# Altium schematic sheet RT_SUPER_B.SchDoc(RT_SUPER_B)
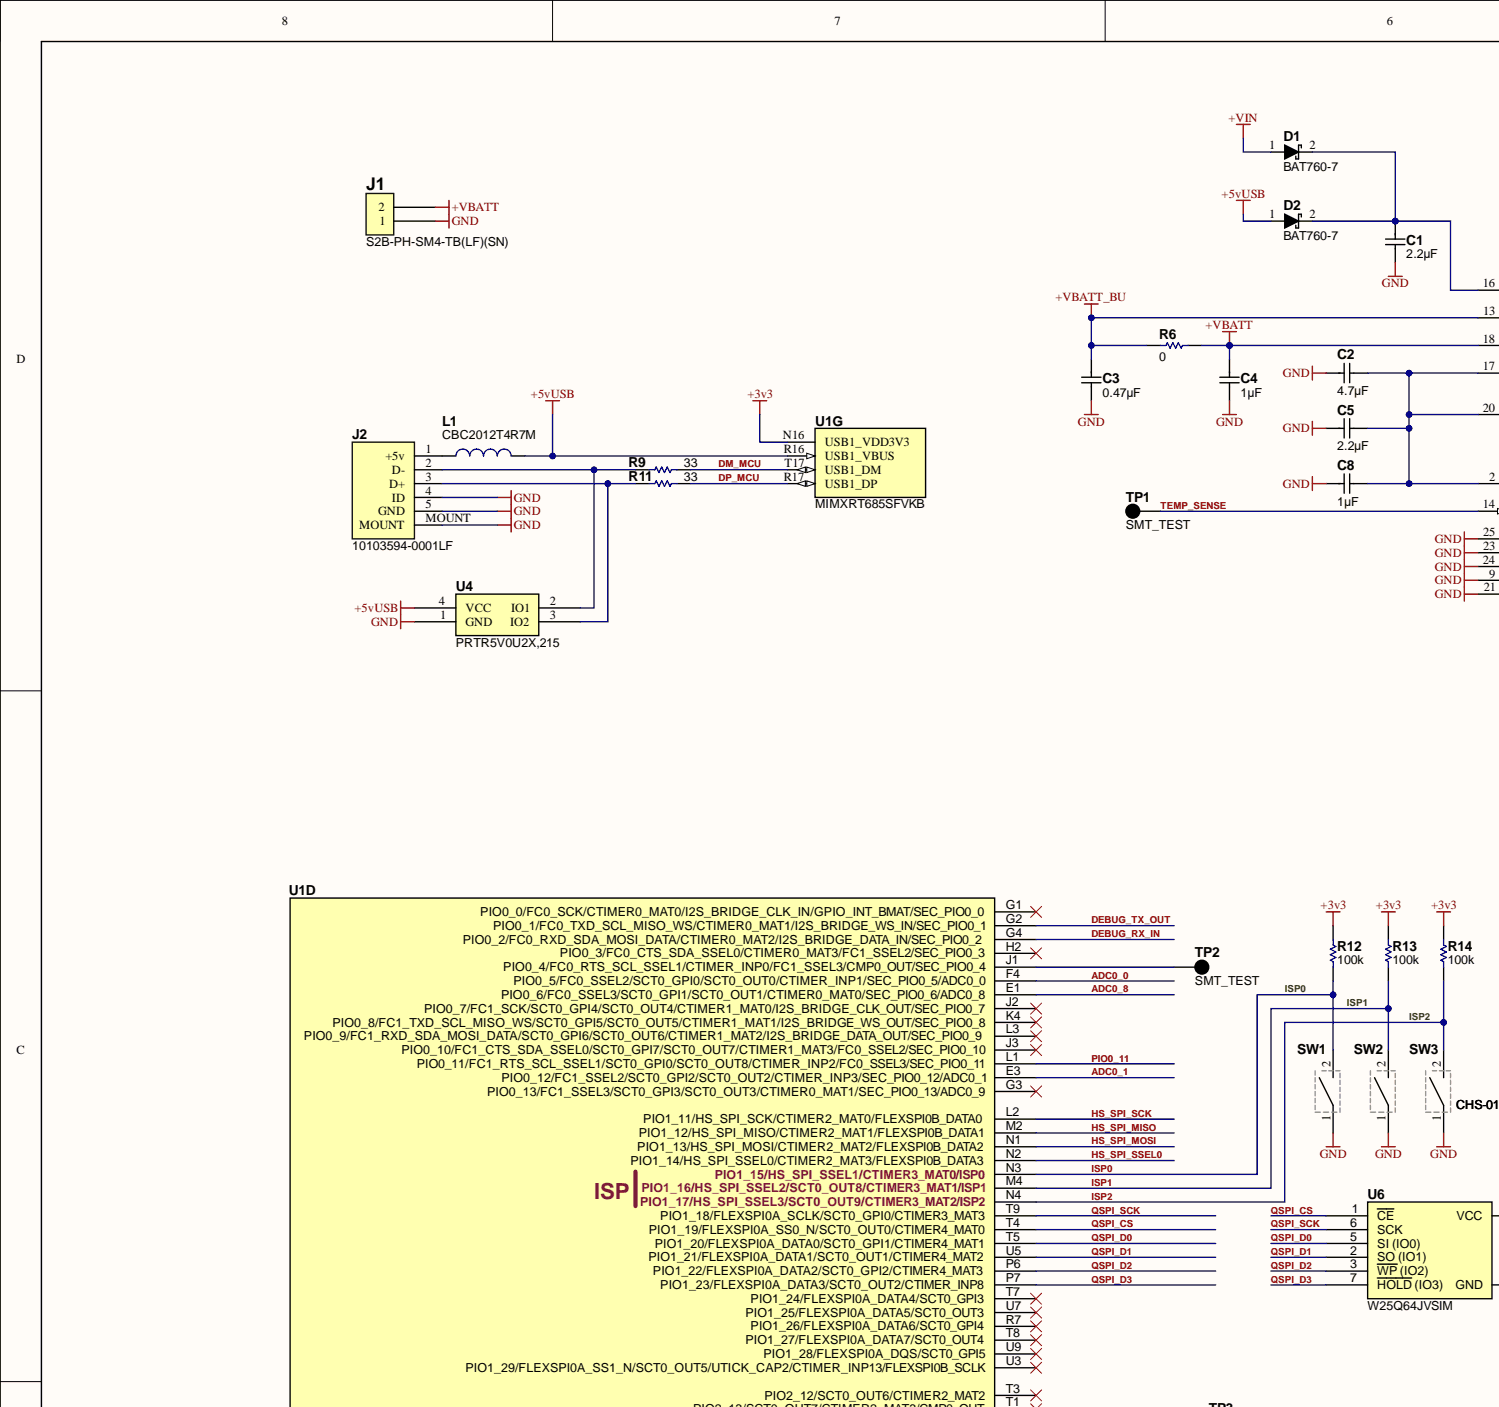
[diagram p0: RT_SUPER_B.SchDoc, top left]
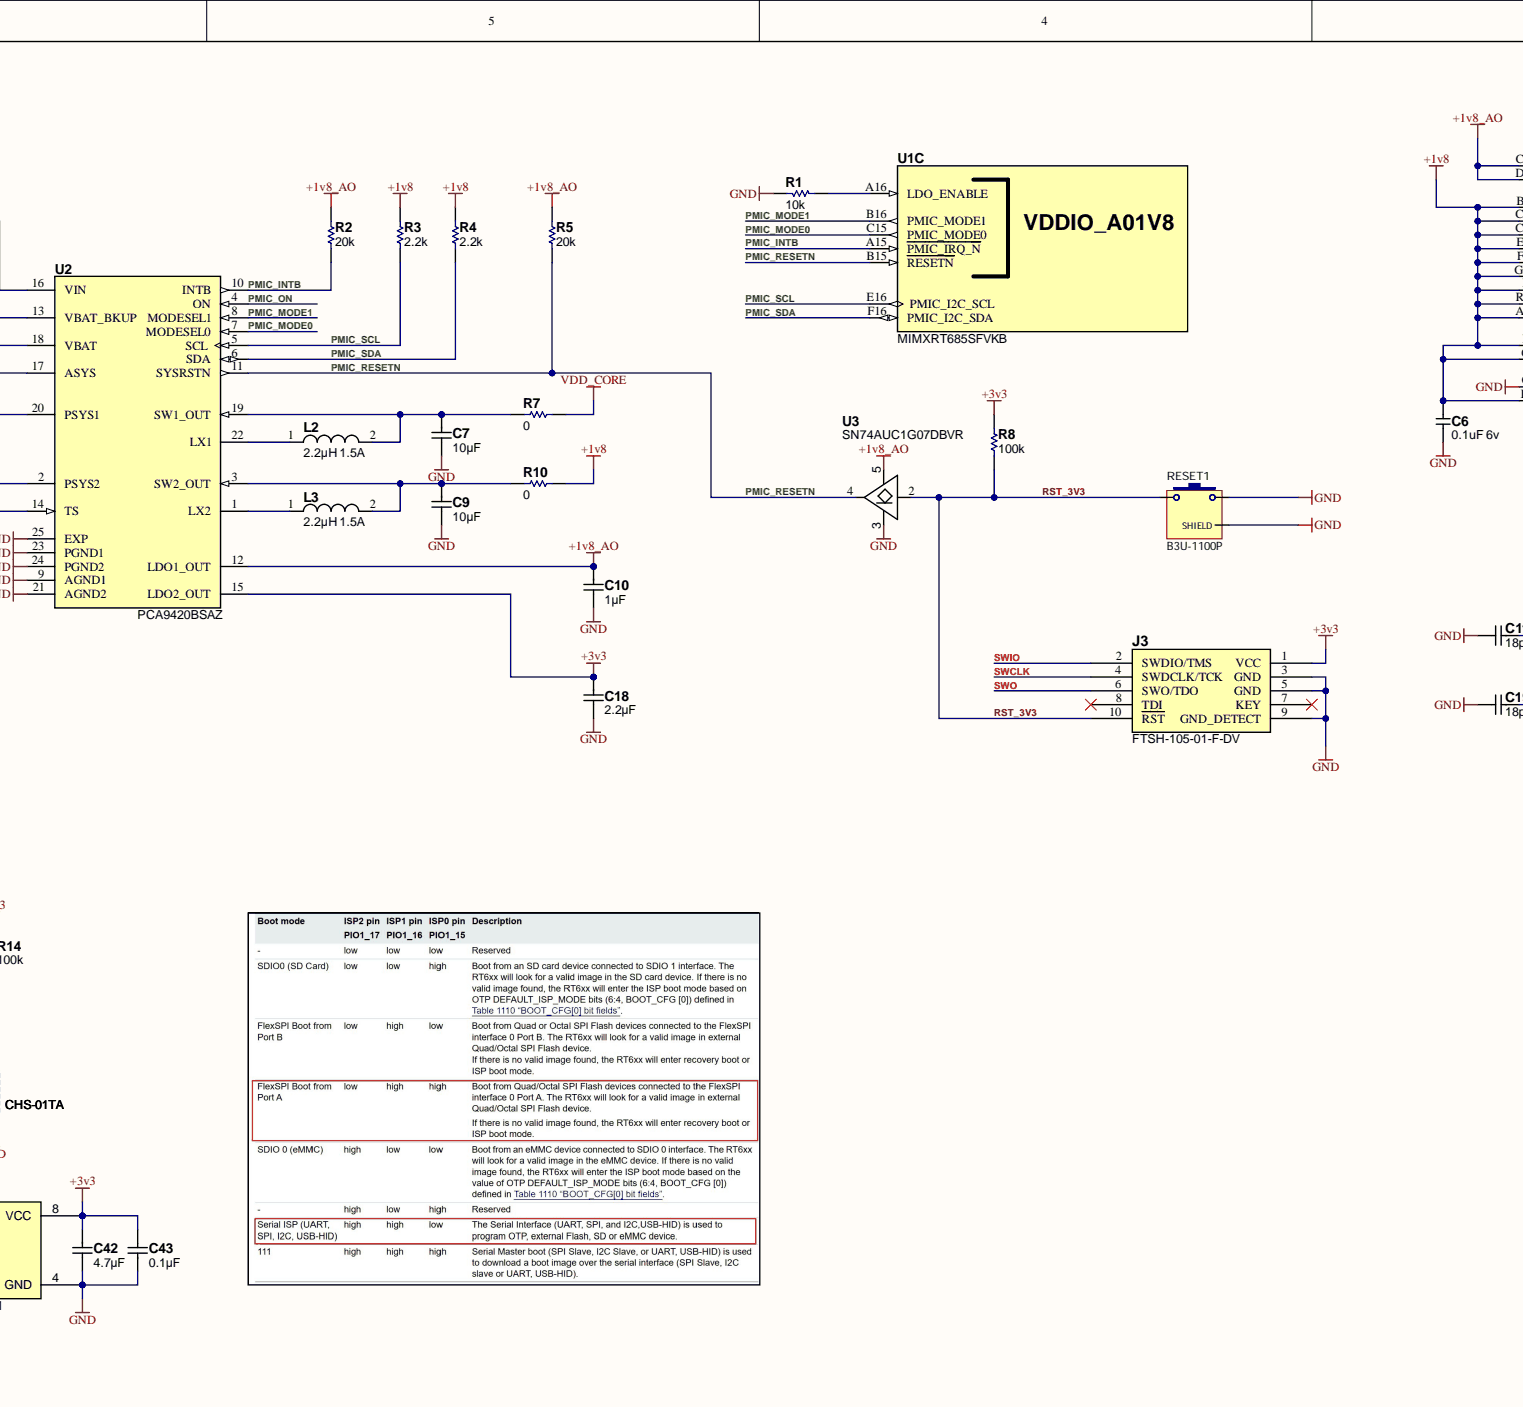
[diagram p1: RT_SUPER_B.SchDoc, top centre]
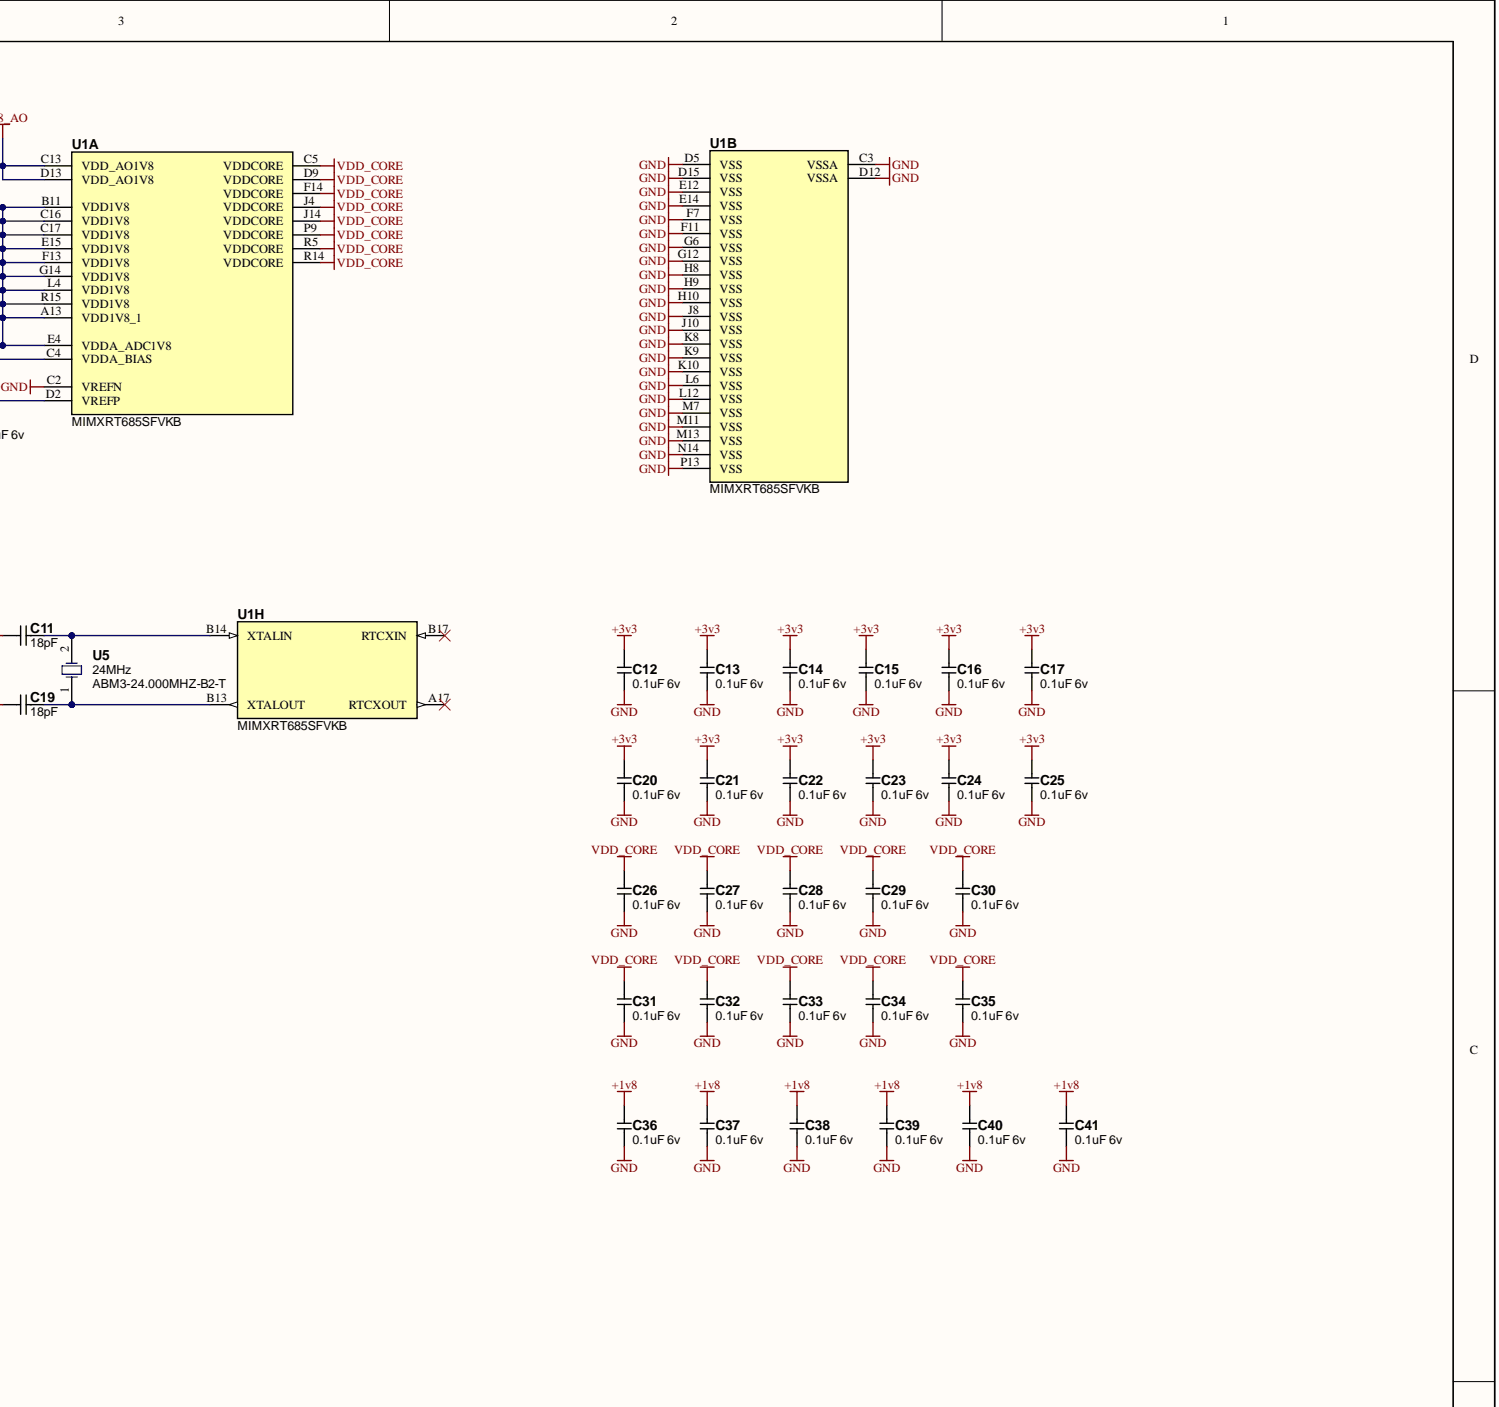
[diagram p2: RT_SUPER_B.SchDoc, top right]
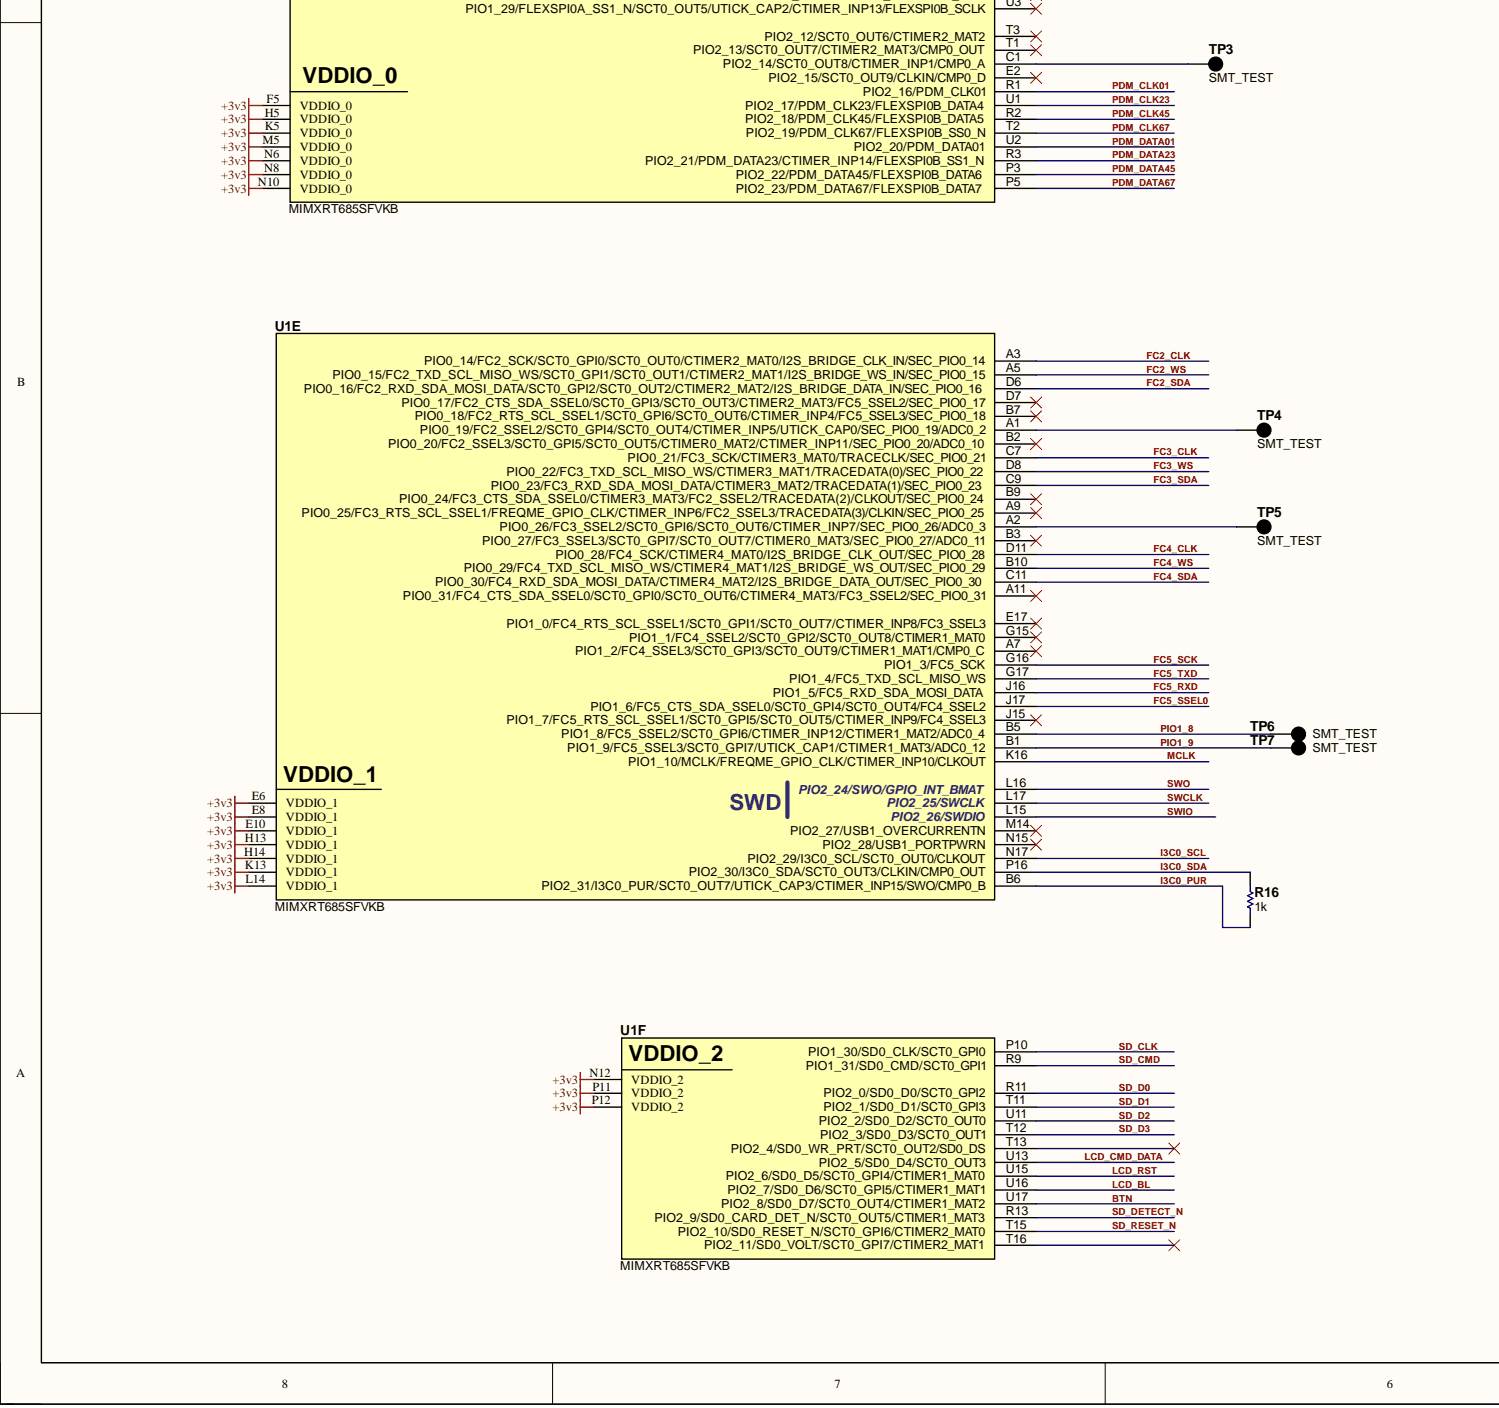
[diagram p3: RT_SUPER_B.SchDoc, bottom left]
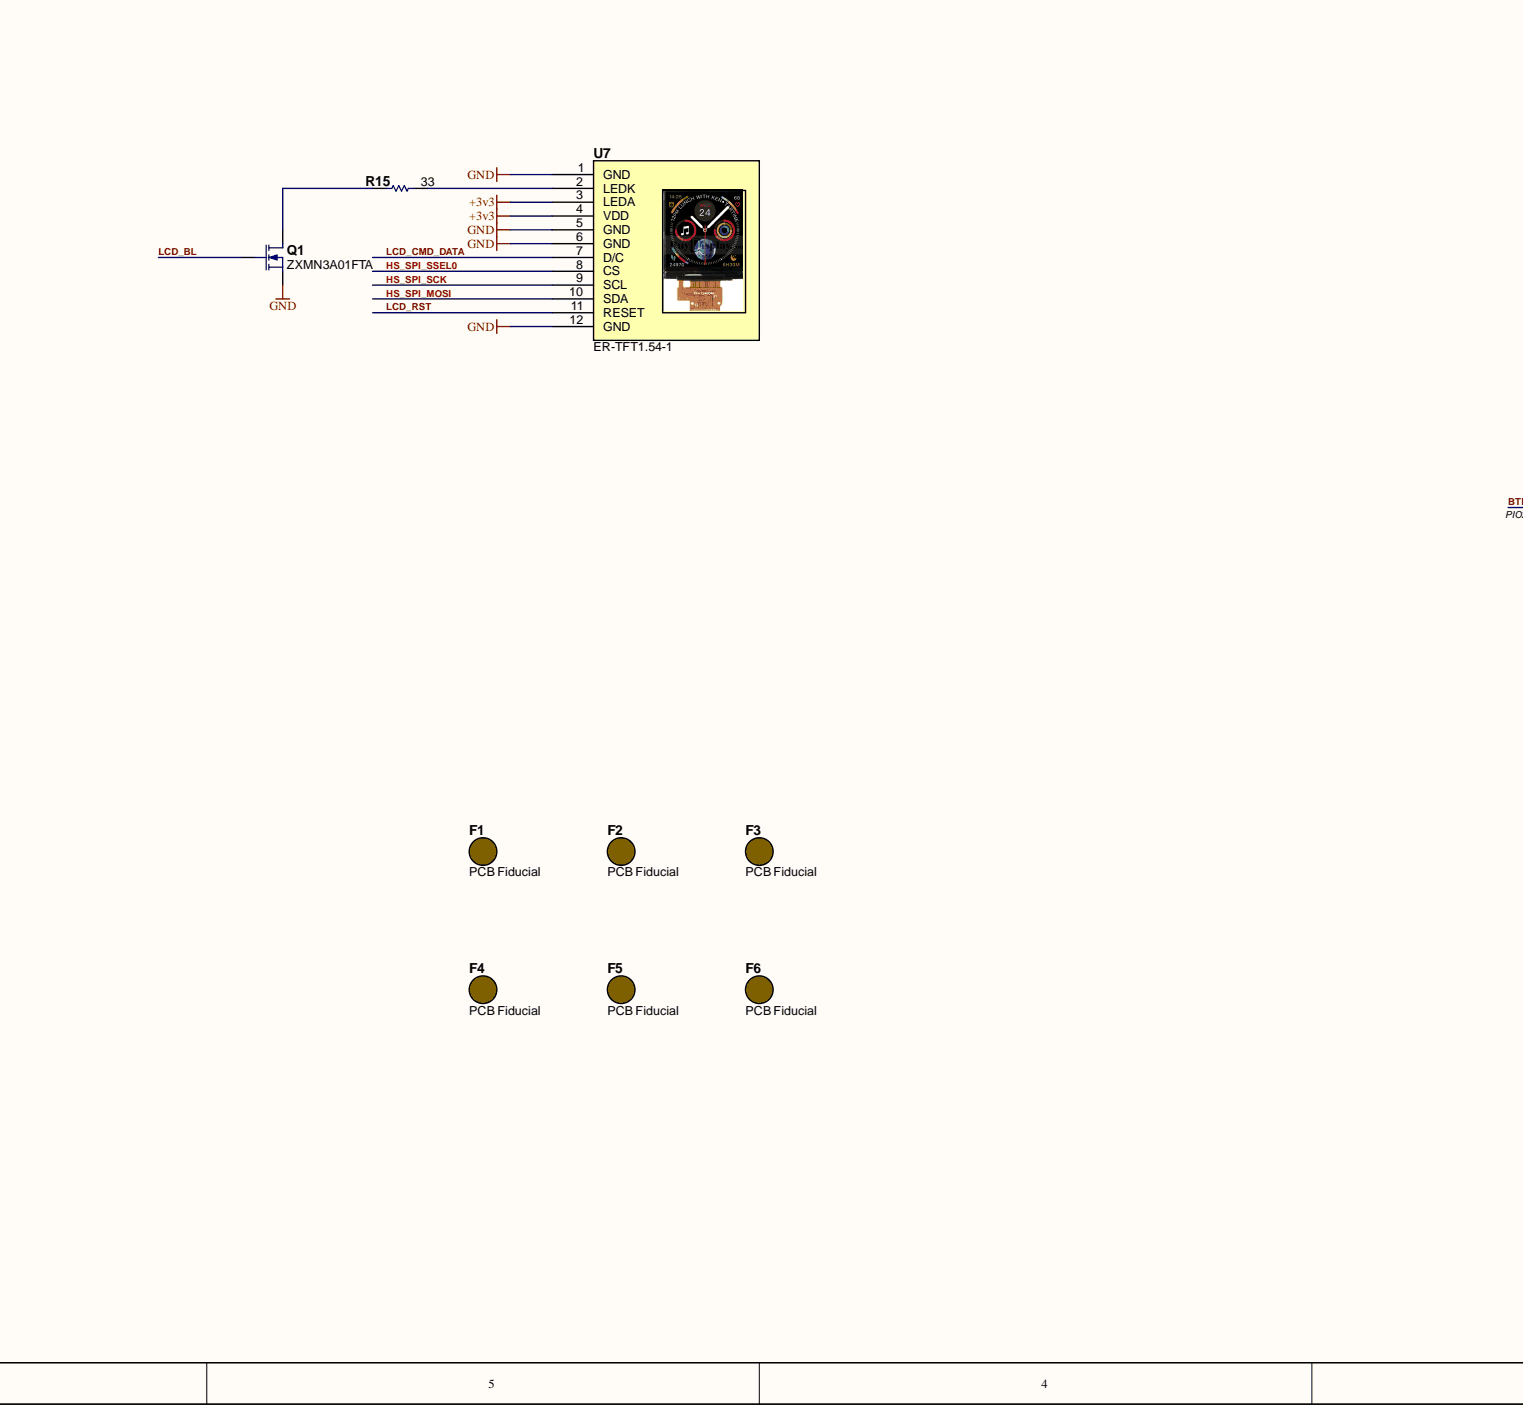
[diagram p4: RT_SUPER_B.SchDoc, bottom centre]
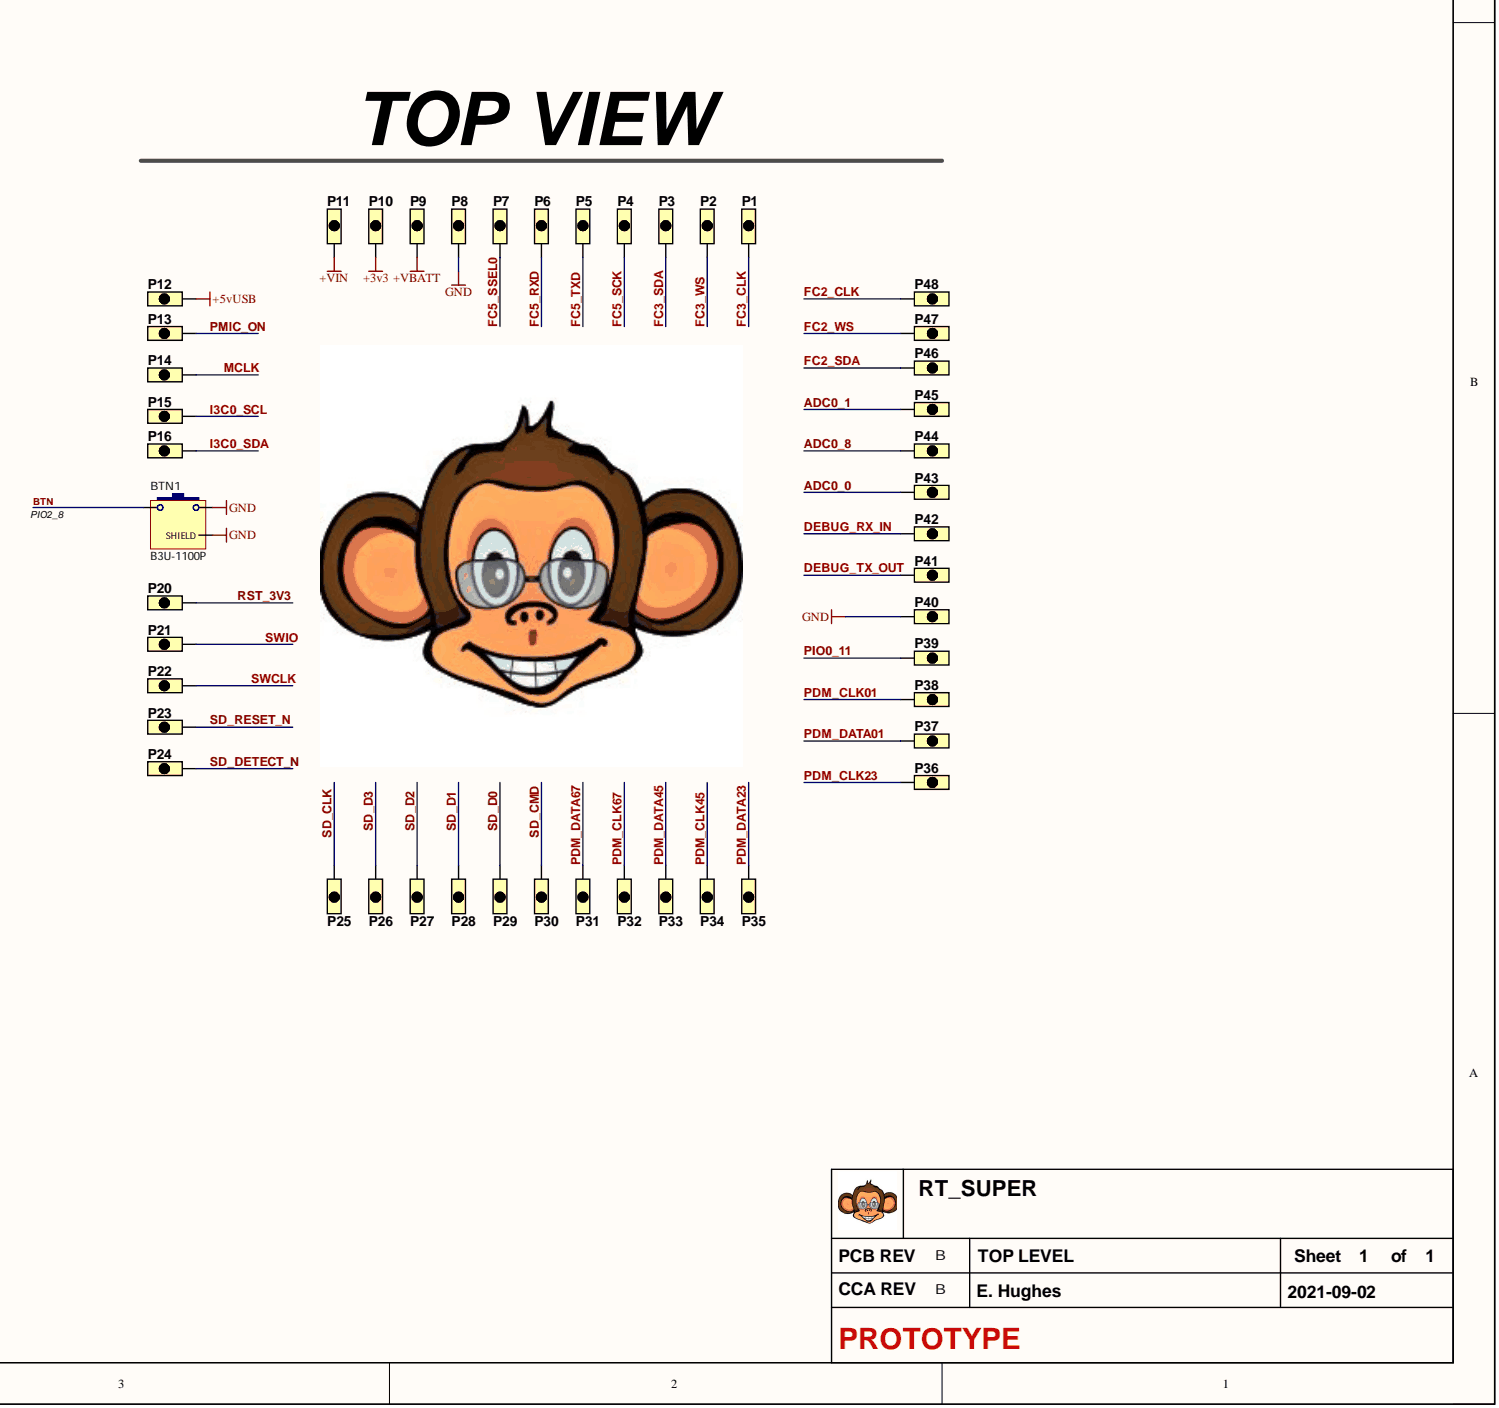
[diagram p5: RT_SUPER_B.SchDoc, bottom right]

## Parts
BTN1  B3U-1100P  [OMRON_B3U-1100P]
C1  2.2µF  [C0603_0.90MM_MD]
C2  4.7µF  [C0603_1.00MM_MD]
C3  0.47µF  [C0402_0.55MM_HD]
C4  1µF  [C0402_0.55MM_HD]
C5  2.2µF  [C0603_0.90MM_MD]
C6  0.1uF 6v  [C0201_PERFECT]
C7  10µF  [C0603_0.90MM_MD]
C8  1µF  [C0402_0.55MM_HD]
C9  10µF  [C0603_0.90MM_MD]
C10  1µF  [C0402_0.55MM_HD]
C11  18pF  [C0402_0.55MM_HD]
C12  0.1uF 6v  [C0201_PERFECT]
C13  0.1uF 6v  [C0201_PERFECT]
C14  0.1uF 6v  [C0201_PERFECT]
C15  0.1uF 6v  [C0201_PERFECT]
C16  0.1uF 6v  [C0201_PERFECT]
C17  0.1uF 6v  [C0201_PERFECT]
C18  2.2µF  [C0603_0.90MM_MD]
C19  18pF  [C0402_0.55MM_HD]
C20  0.1uF 6v  [C0201_PERFECT]
C21  0.1uF 6v  [C0201_PERFECT]
C22  0.1uF 6v  [C0201_PERFECT]
C23  0.1uF 6v  [C0201_PERFECT]
C24  0.1uF 6v  [C0201_PERFECT]
C25  0.1uF 6v  [C0201_PERFECT]
C26  0.1uF 6v  [C0201_PERFECT]
C27  0.1uF 6v  [C0201_PERFECT]
C28  0.1uF 6v  [C0201_PERFECT]
C29  0.1uF 6v  [C0201_PERFECT]
C30  0.1uF 6v  [C0201_PERFECT]
C31  0.1uF 6v  [C0201_PERFECT]
C32  0.1uF 6v  [C0201_PERFECT]
C33  0.1uF 6v  [C0201_PERFECT]
C34  0.1uF 6v  [C0201_PERFECT]
C35  0.1uF 6v  [C0201_PERFECT]
C36  0.1uF 6v  [C0201_PERFECT]
C37  0.1uF 6v  [C0201_PERFECT]
C38  0.1uF 6v  [C0201_PERFECT]
C39  0.1uF 6v  [C0201_PERFECT]
C40  0.1uF 6v  [C0201_PERFECT]
C41  0.1uF 6v  [C0201_PERFECT]
C42  4.7µF  [C0805_1.45MM_MD]
C43  0.1µF  [C0402_0.55MM_MD]
D1  BAT760-7  [D_SOD-323_P]
D2  BAT760-7  [D_SOD-323_P]
F1  PCB Fiducial  [Fiducial 1mm - 2mm]  (no BOM)
F2  PCB Fiducial  [Fiducial 1mm - 2mm]  (no BOM)
F3  PCB Fiducial  [Fiducial 1mm - 2mm]  (no BOM)
F4  PCB Fiducial  [Fiducial 1mm - 2mm]  (no BOM)
F5  PCB Fiducial  [Fiducial 1mm - 2mm]  (no BOM)
F6  PCB Fiducial  [Fiducial 1mm - 2mm]  (no BOM)
J1  S2B-PH-SM4-TB(LF)(SN)  [S2B-PH-SM4-TB(LF)(SN)]
J2  10103594-0001LF  [10103594-0001LF]
J3  FTSH-105-01-F-DV  [FTSH-105-01-F-DV-K-A]
L1  CBC2012T4R7M  [C0805_1.45MM_MD]
L2  2.2µH 1.5A  [L_NRH3012]
L3  2.2µH 1.5A  [L_NRH3012]
P1  (no value)  [CASTELLATED]  (no BOM)
P2  (no value)  [CASTELLATED]  (no BOM)
P3  (no value)  [CASTELLATED]  (no BOM)
P4  (no value)  [CASTELLATED]  (no BOM)
P5  (no value)  [CASTELLATED]  (no BOM)
P6  (no value)  [CASTELLATED]  (no BOM)
P7  (no value)  [CASTELLATED]  (no BOM)
P8  (no value)  [CASTELLATED]  (no BOM)
P9  (no value)  [CASTELLATED]  (no BOM)
P10  (no value)  [CASTELLATED]  (no BOM)
P11  (no value)  [CASTELLATED]  (no BOM)
P12  (no value)  [CASTELLATED]  (no BOM)
P13  (no value)  [CASTELLATED]  (no BOM)
P14  (no value)  [CASTELLATED]  (no BOM)
P15  (no value)  [CASTELLATED]  (no BOM)
P16  (no value)  [CASTELLATED]  (no BOM)
P20  (no value)  [CASTELLATED]  (no BOM)
P21  (no value)  [CASTELLATED]  (no BOM)
P22  (no value)  [CASTELLATED]  (no BOM)
P23  (no value)  [CASTELLATED]  (no BOM)
P24  (no value)  [CASTELLATED]  (no BOM)
P25  (no value)  [CASTELLATED]  (no BOM)
P26  (no value)  [CASTELLATED]  (no BOM)
P27  (no value)  [CASTELLATED]  (no BOM)
P28  (no value)  [CASTELLATED]  (no BOM)
P29  (no value)  [CASTELLATED]  (no BOM)
P30  (no value)  [CASTELLATED]  (no BOM)
P31  (no value)  [CASTELLATED]  (no BOM)
P32  (no value)  [CASTELLATED]  (no BOM)
P33  (no value)  [CASTELLATED]  (no BOM)
P34  (no value)  [CASTELLATED]  (no BOM)
P35  (no value)  [CASTELLATED]  (no BOM)
P36  (no value)  [CASTELLATED]  (no BOM)
P37  (no value)  [CASTELLATED]  (no BOM)
P38  (no value)  [CASTELLATED]  (no BOM)
P39  (no value)  [CASTELLATED]  (no BOM)
P40  (no value)  [CASTELLATED]  (no BOM)
P41  (no value)  [CASTELLATED]  (no BOM)
P42  (no value)  [CASTELLATED]  (no BOM)
P43  (no value)  [CASTELLATED]  (no BOM)
P44  (no value)  [CASTELLATED]  (no BOM)
P45  (no value)  [CASTELLATED]  (no BOM)
P46  (no value)  [CASTELLATED]  (no BOM)
P47  (no value)  [CASTELLATED]  (no BOM)
P48  (no value)  [CASTELLATED]  (no BOM)
Q1  ZXMN3A01FTA  [SOT-23]
R1  10k  [R0402_0.40MM_HD]
R2  20k  [R0402_0.40MM_HD]
R3  2.2k  [R0402_0.40MM_HD]
R4  2.2k  [R0402_0.40MM_HD]
R5  20k  [R0402_0.40MM_HD]
R6  0  [R0402_0.40MM_HD]
R7  0  [R0402_0.40MM_HD]
R8  100k  [R0402_0.40MM_HD]
R9  33  [R0402_0.40MM_HD]
R10  0  [R0402_0.40MM_HD]
R11  33  [R0402_0.40MM_HD]
R12  100k  [R0402_0.40MM_HD]
R13  100k  [R0402_0.40MM_HD]
R14  100k  [R0402_0.40MM_HD]
R15  33  [R0402_0.40MM_HD]
R16  1k  [R0402_0.40MM_HD]
RESET1  B3U-1100P  [OMRON_B3U-1100P]
SW1  CHS-01TA  [CHS-01TA]  (value not drawn)
SW2  CHS-01TA  [CHS-01TA]
SW3  CHS-01TA  [CHS-01TA]
TP1  SMT_TEST  [SMT_TP - 25 x 50]  (no BOM)
TP2  SMT_TEST  [SMT_TP - 35]  (no BOM)
TP3  SMT_TEST  [SMT_TP - 35]  (no BOM)
TP4  SMT_TEST  [SMT_TP - 35]  (no BOM)
TP5  SMT_TEST  [SMT_TP - 35]  (no BOM)
TP6  SMT_TEST  [SMT_TP - 35]  (no BOM)
TP7  SMT_TEST  [SMT_TP - 35]  (no BOM)
U1  MIMXRT685SFVKB  [VFBGA176]  (parts A,B,C,D,E,F,G,H)
U2  PCA9420BSAZ  [PCA9420]
U3  SN74AUC1G07DBVR  [SOT23-5]
U4  PRTR5V0U2X,215  [SOT143B]
U5  24MHz  Load Capacitance: 18pF  [ABM3]
U6  W25Q64JVSIM  [SOIC127P830X200-8L]
U7  ER-TFT1.54-1  [ER-TFT1.54-1]

## Nets
+1v8: C6.2 C36.2 C37.2 C38.2 C39.2 C40.2 C41.2 R3.2 R4.2 R10.2 U1.A13(VDD1V8_1) U1.B11(VDD1V8) U1.C4(VDDA_BIAS) U1.C16(VDD1V8) U1.C17(VDD1V8) U1.D2(VREFP) U1.E4(VDDA_ADC1V8) U1.E15(VDD1V8) U1.F13(VDD1V8) U1.G14(VDD1V8) U1.L4(VDD1V8) U1.R15(VDD1V8)
+1v8_AO: C10.2 R2.2 R5.2 U1.C13(VDD_AO1V8) U1.D13(VDD_AO1V8) U2.12(LDO1_OUT) U3.5
+3v3: C12.2 C13.2 C14.2 C15.2 C16.2 C17.2 C18.2 C20.2 C21.2 C22.2 C23.2 C24.2 C25.2 C42.2 C43.1 J3.1(VCC) P10.1 R8.2 R12.2 R13.2 R14.2 U1.E6(VDDIO_1) U1.E8(VDDIO_1) U1.E10(VDDIO_1) U1.F5(VDDIO_0) U1.H5(VDDIO_0) U1.H13(VDDIO_1) U1.H14(VDDIO_1) U1.K5(VDDIO_0) U1.K13(VDDIO_1) U1.L14(VDDIO_1) U1.M5(VDDIO_0) U1.N6(VDDIO_0) U1.N8(VDDIO_0) U1.N10(VDDIO_0) U1.N12(VDDIO_2) U1.N16(USB1_VDD3V3) U1.P11(VDDIO_2) U1.P12(VDDIO_2) U2.15(LDO2_OUT) U6.8(VCC) U7.3(LEDA) U7.4(VDD)
+5vUSB: D2.1 L1.2 P12.1 U1.R16(USB1_VBUS) U4.4(VCC)
+VBATT: C4.2 J1.2 P9.1 R6.2 U2.18(VBAT)
+VBATT_BU: C3.2 R6.1 U2.13(VBAT_BKUP)
+VIN: D1.1 P11.1
GND: BTN1.1 BTN1.S C1.1 C2.2 C3.1 C4.1 C5.2 C6.1 C7.1 C8.2 C9.1 C10.1 C11.1 C12.1 C13.1 C14.1 C15.1 C16.1 C17.1 C18.1 C19.1 C20.1 C21.1 C22.1 C23.1 C24.1 C25.1 C26.1 C27.1 C28.1 C29.1 C30.1 C31.1 C32.1 C33.1 C34.1 C35.1 C36.1 C37.1 C38.1 C39.1 C40.1 C41.1 C42.1 C43.2 J1.1 J2.4(ID) J2.5(GND) J2.MOUNT J3.3(GND) J3.5(GND) J3.9(GND_DETECT) P8.1 P40.1 Q1.2 R1.1 RESET1.1 RESET1.S SW1.1 SW2.1 SW3.1 U1.C2(VREFN) U1.C3(VSSA) U1.D5(VSS) U1.D12(VSSA) U1.D15(VSS) U1.E12(VSS) U1.E14(VSS) U1.F7(VSS) U1.F11(VSS) U1.G6(VSS) U1.G12(VSS) U1.H8(VSS) U1.H9(VSS) U1.H10(VSS) U1.J8(VSS) U1.J10(VSS) U1.K8(VSS) U1.K9(VSS) U1.K10(VSS) U1.L6(VSS) U1.L12(VSS) U1.M7(VSS) U1.M11(VSS) U1.M13(VSS) U1.N14(VSS) U1.P13(VSS) U2.9(AGND1) U2.21(AGND2) U2.23(PGND1) U2.24(PGND2) U2.25(EXP) U3.3 U4.1(GND) U6.4(GND) U7.1(GND) U7.5(GND) U7.6(GND) U7.12(GND)
VDD_CORE: C26.2 C27.2 C28.2 C29.2 C30.2 C31.2 C32.2 C33.2 C34.2 C35.2 R7.2 U1.C5(VDDCORE) U1.D9(VDDCORE) U1.F14(VDDCORE) U1.J4(VDDCORE) U1.J14(VDDCORE) U1.P9(VDDCORE) U1.R5(VDDCORE) U1.R14(VDDCORE)
ADC0_0: P43.1 U1.F4
ADC0_1: P45.1 U1.E3
ADC0_8: P44.1 U1.E1
BTN: BTN1.2 U1.U17(PIO2_8/SD0_D7/SCT0_OUT4/CTIMER1_MAT2)
DEBUG_RX_IN: P42.1 U1.G4
DEBUG_TX_OUT: P41.1 U1.G2
DM_MCU: R9.2 U1.T17(USB1_DM)
DP_MCU: R11.2 U1.R17(USB1_DP)
FC2_CLK: P48.1 U1.A3
FC2_SDA: P46.1 U1.D6
FC2_WS: P47.1 U1.A5
FC3_CLK: P1.1 U1.C7
FC3_SDA: P3.1 U1.C9
FC3_WS: P2.1 U1.D8
FC4_CLK: U1.D11
FC4_SDA: U1.C11
FC4_WS: U1.B10
FC5_RXD: P6.1 U1.J16(PIO1_5/FC5_RXD_SDA_MOSI_DATA)
FC5_SCK: P4.1 U1.G16(PIO1_3/FC5_SCK)
FC5_SSEL0: P7.1 U1.J17(PIO1_6/FC5_CTS_SDA_SSEL0/SCT0_GPI4/SCT0_OUT4/FC4_SSEL2)
FC5_TXD: P5.1 U1.G17(PIO1_4/FC5_TXD_SCL_MISO_WS)
HS_SPI_MISO: U1.M2(PIO1_12/HS_SPI_MISO/CTIMER2_MAT1/FLEXSPI0B_DATA1)
HS_SPI_MOSI: U1.N1(PIO1_13/HS_SPI_MOSI/CTIMER2_MAT2/FLEXSPI0B_DATA2) U7.10(SDA)
HS_SPI_SCK: U1.L2(PIO1_11/HS_SPI_SCK/CTIMER2_MAT0/FLEXSPI0B_DATA0) U7.9(SCL)
HS_SPI_SSEL0: U1.N2(PIO1_14/HS_SPI_SSEL0/CTIMER2_MAT3/FLEXSPI0B_DATA3) U7.8(CS)
I3C0_PUR: R16.1 U1.B6(PIO2_31/I3C0_PUR/SCT0_OUT7/UTICK_CAP3/CTIMER_INP15/SWO/CMP0_B)
I3C0_SCL: P15.1 U1.N17(PIO2_29/I3C0_SCL/SCT0_OUT0/CLKOUT)
I3C0_SDA: P16.1 R16.2 U1.P16(PIO2_30/I3C0_SDA/SCT0_OUT3/CLKIN/CMP0_OUT)
ISP0: R12.1 SW1.2 U1.N3(PIO1_15/HS_SPI_SSEL1/CTIMER3_MAT0/ISP0)
ISP1: R13.1 SW2.2 U1.M4(PIO1_16/HS_SPI_SSEL2/SCT0_OUT8/CTIMER3_MAT1/ISP1)
ISP2: R14.1 SW3.2 U1.N4(PIO1_17/HS_SPI_SSEL3/SCT0_OUT9/CTIMER3_MAT2/ISP2)
LCD_BL: Q1.1 U1.U16(PIO2_7/SD0_D6/SCT0_GPI5/CTIMER1_MAT1)
LCD_CMD_DATA: U1.U13(PIO2_5/SD0_D4/SCT0_OUT3) U7.7(D/C)
LCD_RST: U1.U15(PIO2_6/SD0_D5/SCT0_GPI4/CTIMER1_MAT0) U7.11(RESET)
MCLK: P14.1 U1.K16(PIO1_10/MCLK/FREQME_GPIO_CLK/CTIMER_INP10/CLKOUT)
PDM_CLK01: P38.1 U1.R1(PIO2_16/PDM_CLK01)
PDM_CLK23: P36.1 U1.U1(PIO2_17/PDM_CLK23/FLEXSPI0B_DATA4)
PDM_CLK45: P34.1 U1.R2(PIO2_18/PDM_CLK45/FLEXSPI0B_DATA5)
PDM_CLK67: P32.1 U1.T2(PIO2_19/PDM_CLK67/FLEXSPI0B_SS0_N)
PDM_DATA01: P37.1 U1.U2(PIO2_20/PDM_DATA01)
PDM_DATA23: P35.1 U1.R3(PIO2_21/PDM_DATA23/CTIMER_INP14/FLEXSPI0B_SS1_N)
PDM_DATA45: P33.1 U1.P3(PIO2_22/PDM_DATA45/FLEXSPI0B_DATA6)
PDM_DATA67: P31.1 U1.P5(PIO2_23/PDM_DATA67/FLEXSPI0B_DATA7)
PIO0_11: P39.1 U1.L1
PIO1_8: TP6.1 U1.B5(PIO1_8/FC5_SSEL2/SCT0_GPI6/CTIMER_INP12/CTIMER1_MAT2/ADC0_4)
PIO1_9: TP7.1 U1.B1(PIO1_9/FC5_SSEL3/SCT0_GPI7/UTICK_CAP1/CTIMER1_MAT3/ADC0_12)
PMIC_INTB: R2.1 U1.A15(PMIC_IRQ_N) U2.10(INTB)
PMIC_MODE0: U1.C15(PMIC_MODE0) U2.7(MODESEL0)
PMIC_MODE1: U1.B16(PMIC_MODE1) U2.8(MODESEL1)
PMIC_ON: P13.1 U2.4(ON)
PMIC_RESETN: R5.1 U1.B15(RESETN) U2.11(SYSRSTN) U3.4
PMIC_SCL: R3.1 U1.E16(PMIC_I2C_SCL) U2.5(SCL)
PMIC_SDA: R4.1 U1.F16(PMIC_I2C_SDA) U2.6(SDA)
QSPI_CS: U1.T4(PIO1_19/FLEXSPI0A_SS0_N/SCT0_OUT0/CTIMER4_MAT0) U6.1(CE)
QSPI_D0: U1.T5(PIO1_20/FLEXSPI0A_DATA0/SCT0_GPI1/CTIMER4_MAT1) U6.5(SI_(IO0))
QSPI_D1: U1.U5(PIO1_21/FLEXSPI0A_DATA1/SCT0_OUT1/CTIMER4_MAT2) U6.2(SO_(IO1))
QSPI_D2: U1.P6(PIO1_22/FLEXSPI0A_DATA2/SCT0_GPI2/CTIMER4_MAT3) U6.3(WP_(IO2))
QSPI_D3: U1.P7(PIO1_23/FLEXSPI0A_DATA3/SCT0_OUT2/CTIMER_INP8) U6.7(HOLD_(IO3))
QSPI_SCK: U1.T9(PIO1_18/FLEXSPI0A_SCLK/SCT0_GPI0/CTIMER3_MAT3) U6.6(SCK)
RST_3V3: J3.10(RST) P20.1 R8.1 RESET1.2 U3.2
SD_CLK: P25.1 U1.P10(PIO1_30/SD0_CLK/SCT0_GPI0)
SD_CMD: P30.1 U1.R9(PIO1_31/SD0_CMD/SCT0_GPI1)
SD_D0: P29.1 U1.R11(PIO2_0/SD0_D0/SCT0_GPI2)
SD_D1: P28.1 U1.T11(PIO2_1/SD0_D1/SCT0_GPI3)
SD_D2: P27.1 U1.U11(PIO2_2/SD0_D2/SCT0_OUT0)
SD_D3: P26.1 U1.T12(PIO2_3/SD0_D3/SCT0_OUT1)
SD_DETECT_N: P24.1 U1.R13(PIO2_9/SD0_CARD_DET_N/SCT0_OUT5/CTIMER1_MAT3)
SD_RESET_N: P23.1 U1.T15(PIO2_10/SD0_RESET_N/SCT0_GPI6/CTIMER2_MAT0)
SWCLK: J3.4(SWDCLK/TCK) P22.1 U1.L17(PIO2_25/SWCLK)
SWIO: J3.2(SWDIO/TMS) P21.1 U1.L15(PIO2_26/SWDIO)
SWO: J3.6(SWO/TDO) U1.L16(PIO2_24/SWO/GPIO_INT_BMAT)
TEMP_SENSE: TP1.1 U2.14(TS)
NetC1_2: C1.2 D1.2 D2.2 U2.16(VIN)
NetC2_1: C2.1 C5.1 C8.1 U2.2(PSYS2) U2.17(ASYS) U2.20(PSYS1)
NetC7_2: C7.2 L2.2 R7.1 U2.19(SW1_OUT)
NetC9_2: C9.2 L3.2 R10.1 U2.3(SW2_OUT)
NetC11_2: C11.2 U1.B14(XTALIN) U5.2
NetC19_2: C19.2 U1.B13(XTALOUT) U5.1
NetJ2_1: J2.1(+5v) L1.1
NetJ2_2: J2.2(D-) R9.1 U4.2(IO1)
NetJ2_3: J2.3(D+) R11.1 U4.3(IO2)
NetL2_1: L2.1 U2.22(LX1)
NetL3_1: L3.1 U2.1(LX2)
NetQ1_3: Q1.3 R15.1
NetR1_2: R1.2 U1.A16(LDO_ENABLE)
NetR15_2: R15.2 U7.2(LEDK)
NetTP2_1: TP2.1 U1.J1
NetTP3_1: TP3.1 U1.C1(PIO2_14/SCT0_OUT8/CTIMER_INP1/CMP0_A)
NetTP4_1: TP4.1 U1.A1
NetTP5_1: TP5.1 U1.A2

Not connected: J3 7(KEY) 8(TDI); U1 A7(PIO1_2/FC4_SSEL3/SCT0_GPI3/SCT0_OUT9/CTIMER1_MAT1/CMP0_C) A9 A11 A17(RTCXOUT) B2 B3 B7 B9 B17(RTCXIN) D7 E2(PIO2_15/SCT0_OUT9/CLKIN/CMP0_D) E17(PIO1_0/FC4_RTS_SCL_SSEL1/SCT0_GPI1/SCT0_OUT7/CTIMER_INP8/FC3_SSEL3) G1 G3 G15(PIO1_1/FC4_SSEL2/SCT0_GPI2/SCT0_OUT8/CTIMER1_MAT0) H2 J2 J3 J15(PIO1_7/FC5_RTS_SCL_SSEL1/SCT0_GPI5/SCT0_OUT5/CTIMER_INP9/FC4_SSEL3) K4 L3 M14(PIO2_27/USB1_OVERCURRENTN) N15(PIO2_28/USB1_PORTPWRN) R7(PIO1_26/FLEXSPI0A_DATA6/SCT0_GPI4) T1(PIO2_13/SCT0_OUT7/CTIMER2_MAT3/CMP0_OUT) T3(PIO2_12/SCT0_OUT6/CTIMER2_MAT2) T7(PIO1_24/FLEXSPI0A_DATA4/SCT0_GPI3) T8(PIO1_27/FLEXSPI0A_DATA7/SCT0_OUT4) T13(PIO2_4/SD0_WR_PRT/SCT0_OUT2/SD0_DS) T16(PIO2_11/SD0_VOLT/SCT0_GPI7/CTIMER2_MAT1) U3(PIO1_29/FLEXSPI0A_SS1_N/SCT0_OUT5/UTICK_CAP2/CTIMER_INP13/FLEXSPI0B_SCLK) U7(PIO1_25/FLEXSPI0A_DATA5/SCT0_OUT3) U9(PIO1_28/FLEXSPI0A_DQS/SCT0_GPI5)
